# Altium schematic sheet [10] - USB-JTAG, USB-UART.SchDoc("[10] - USB-JTAG, USB-UART")
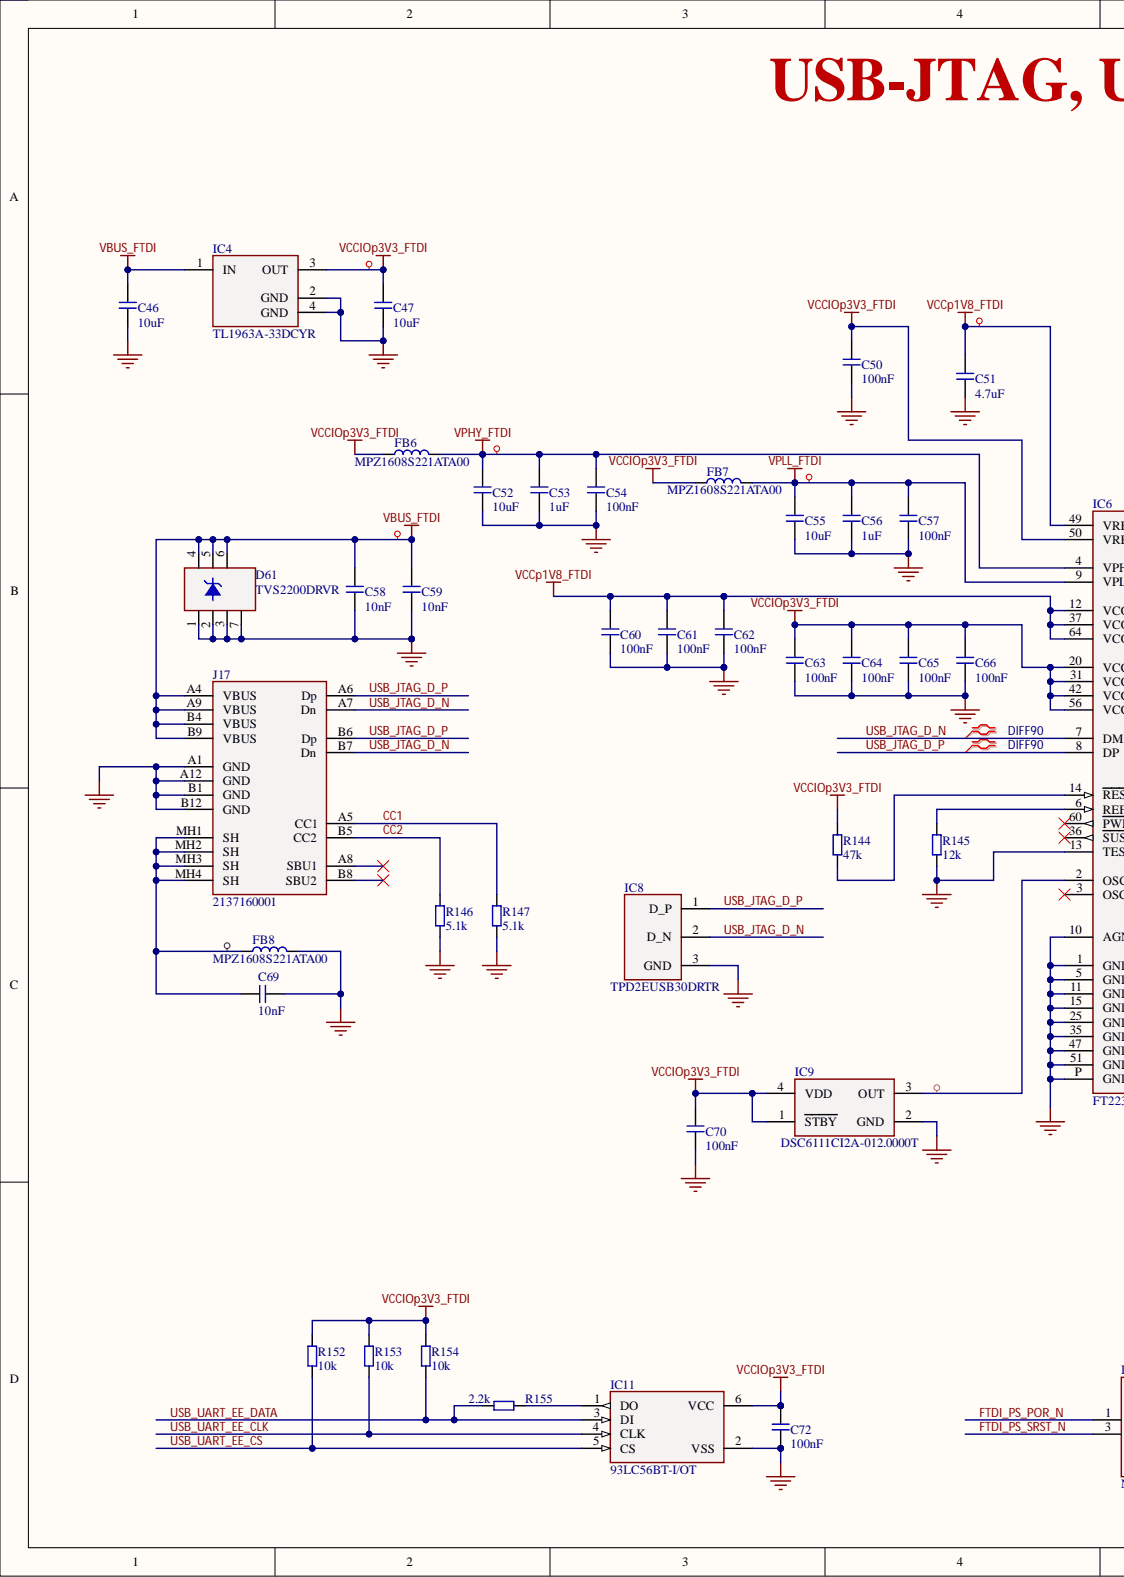
[diagram p0: [10] - USB-JTAG, USB-UART.SchDoc, left]
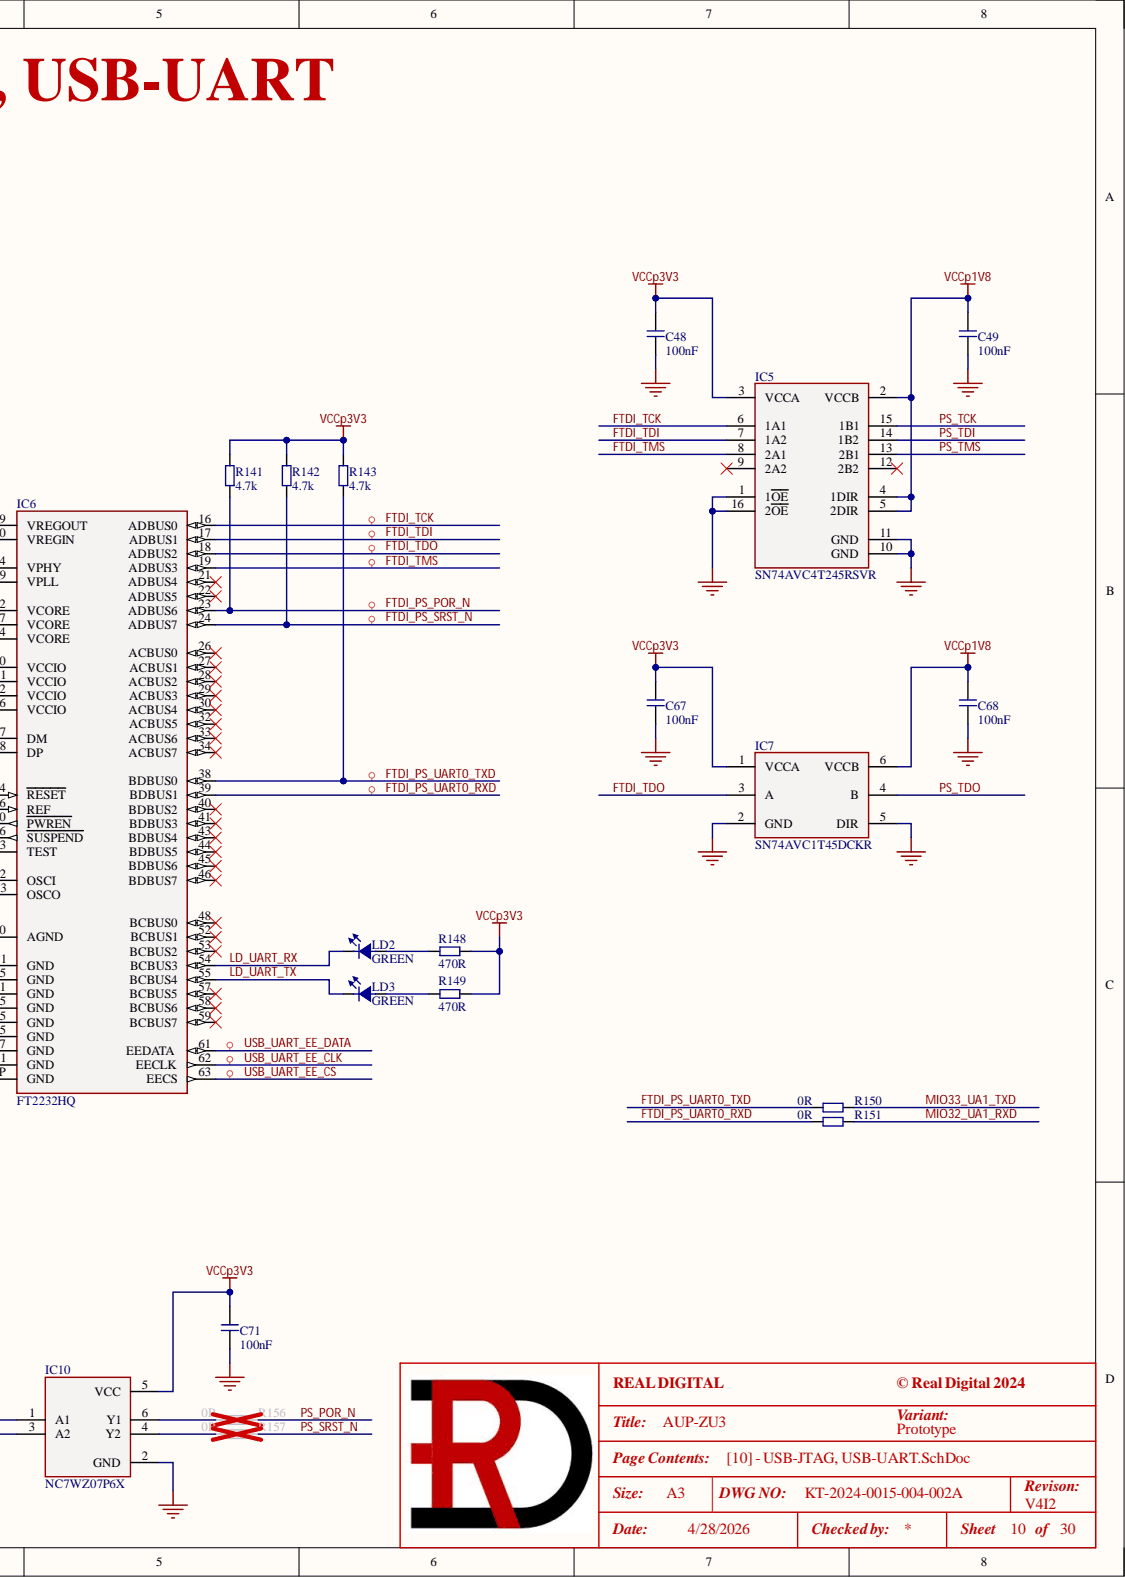
[diagram p1: [10] - USB-JTAG, USB-UART.SchDoc, right]

## Parts
C46  10uF  [C0805]
C47  10uF  [C0402]
C48  100nF  [C0402]
C49  100nF  [C0402]
C50  100nF  [C0402]
C51  4.7uF  [C0402]
C52  10uF  [C0805]
C53  1uF  [C0603]
C54  100nF  [C0402]
C55  10uF  [C0805]
C56  1uF  [C0603]
C57  100nF  [C0402]
C58  10nF  [C0402]
C59  10nF  [C0402]
C60  100nF  [C0402]
C61  100nF  [C0402]
C62  100nF  [C0402]
C63  100nF  [C0402]
C64  100nF  [C0402]
C65  100nF  [C0402]
C66  100nF  [C0402]
C67  100nF  [C0402]
C68  100nF  [C0402]
C69  10nF  [C0402]
C70  100nF  [C0402]
C71  100nF  [C0402]
C72  100nF  [C0402]
D61  TVS2200DRVR  [DRV0006A_WSON_6 - TI]
FB6  MPZ1608S221ATA00  [MPZ1608]
FB7  MPZ1608S221ATA00  [MPZ1608]
FB8  MPZ1608S221ATA00  [MPZ1608]
IC4  TL1963A-33DCYR  [DCY0004A_SOT223-4 - TI]
IC5  SN74AVC4T245RSVR  [RSV0016A_UQFN_16 - TI]
IC6  FT2232HQ  [QFN64 - FTDI]
IC7  SN74AVC1T45DCKR  [DCK0006A_SC70-6 - TI]
IC8  TPD2EUSB30DRTR  [DRT0003A - TI]
IC9  DSC6111CI2A-012.0000T  [DSC3.2x2.5-4]
IC10  NC7WZ07P6X  [DCK0006A_SC70-6 - TI]
IC11  93LC56BT-I/OT  [SOT23-6 - Microchip]
J17  2137160001  [2137160001]
LD2  GREEN  [LD0603_Green]
LD3  GREEN  [LD0603_Green]
R141  4.7k  [R0402]
R142  4.7k  [R0402]
R143  4.7k  [R0402]
R144  47k  [R0402]
R145  12k  [R0402]
R146  5.1k  [R0402]
R147  5.1k  [R0402]
R148  470R  [R0402]
R149  470R  [R0402]
R150  0R  [R0402]
R151  0R  [R0402]
R152  10k  [R0402]
R153  10k  [R0402]
R154  10k  [R0402]
R155  2.2k  [R0402]
R156  0R  [R0402]
R157  0R  [R0402]

## Nets
GND: C46.1 C47.1 C48.1 C49.1 C50.1 C51.1 C52.1 C53.1 C54.1 C55.1 C56.1 C57.1 C58.1 C59.1 C60.1 C61.1 C62.1 C63.1 C64.1 C65.1 C66.1 C67.1 C68.1 C69.2 C70.1 C71.1 C72.1 D61.1 D61.2 D61.3 D61.7 FB8.2 IC4.2(GND) IC4.4(GND) IC5.1(1OE) IC5.10(GND) IC5.11(GND) IC5.16(2OE) IC6.1(GND) IC6.5(GND) IC6.10(AGND) IC6.11(GND) IC6.13(TEST) IC6.15(GND) IC6.25(GND) IC6.35(GND) IC6.47(GND) IC6.51(GND) IC6.P(GND) IC7.2(GND) IC7.5(DIR) IC8.3 IC9.2(GND) IC10.2(GND) IC11.2(VSS) J17.A1(GND) J17.A12(GND) J17.B1(GND) J17.B12(GND) R145.1 R146.1 R147.1
VBUS_FTDI: C46.2 C58.2 C59.2 D61.4 D61.5 D61.6 IC4.1(IN) J17.A4(VBUS) J17.A9(VBUS) J17.B4(VBUS) J17.B9(VBUS)
VCCIOp3V3_FTDI: C47.2 C50.2 C63.2 C64.2 C65.2 C66.2 C70.2 C72.2 FB6.1 FB7.1 IC4.3(OUT) IC6.20(VCCIO) IC6.31(VCCIO) IC6.42(VCCIO) IC6.50(VREGIN) IC6.56(VCCIO) IC9.1(STBY) IC9.4(VDD) IC11.6(VCC) R144.2 R152.2 R153.2 R154.2
VCCp1V8: C49.2 C68.2 IC5.2(VCCB) IC5.4(1DIR) IC5.5(2DIR) IC7.6(VCCB)
VCCp1V8_FTDI: C51.2 C60.2 C61.2 C62.2 IC6.12(VCORE) IC6.37(VCORE) IC6.49(VREGOUT) IC6.64(VCORE)
VCCp3V3: C48.2 C67.2 C71.2 IC5.3(VCCA) IC7.1(VCCA) IC10.5(VCC) R141.2 R142.2 R143.2 R148.2 R149.2
VPHY_FTDI: C52.2 C53.2 C54.2 FB6.2 IC6.4(VPHY)
VPLL_FTDI: C55.2 C56.2 C57.2 FB7.2 IC6.9(VPLL)
CC1: J17.A5(CC1) R147.2
CC2: J17.B5(CC2) R146.2
FTDI_PS_POR_N: IC6.23(ADBUS6) IC10.1(A1) R141.1
FTDI_PS_SRST_N: IC6.24(ADBUS7) IC10.3(A2) R142.1
FTDI_PS_UART0_RXD: IC6.39(BDBUS1) R151.1
FTDI_PS_UART0_TXD: IC6.38(BDBUS0) R143.1 R150.1
FTDI_TCK: IC5.6(1A1) IC6.16(ADBUS0)
FTDI_TDI: IC5.7(1A2) IC6.17(ADBUS1)
FTDI_TDO: IC6.18(ADBUS2) IC7.3(A)
FTDI_TMS: IC5.8(2A1) IC6.19(ADBUS3)
LD_UART_RX: IC6.54(BCBUS3) LD2.K
LD_UART_TX: IC6.55(BCBUS4) LD3.K
MIO32_UA1_RXD: R151.2
MIO33_UA1_TXD: R150.2
PS_POR_N: R156.2
PS_SRST_N: R157.2
PS_TCK: IC5.15(1B1)
PS_TDI: IC5.14(1B2)
PS_TDO: IC7.4(B)
PS_TMS: IC5.13(2B1)
USB_JTAG_D_N: IC6.7(DM) IC8.2 J17.A7(Dn) J17.B7(Dn)
USB_JTAG_D_P: IC6.8(DP) IC8.1 J17.A6(Dp) J17.B6(Dp)
USB_UART_EE_CLK: IC6.62(EECLK) IC11.4(CLK) R153.1
USB_UART_EE_CS: IC6.63(EECS) IC11.5(CS) R152.1
USB_UART_EE_DATA: IC6.61(EEDATA) IC11.3(DI) R154.1 R155.2
NetC69_1: C69.1 FB8.1 J17.MH1(SH) J17.MH2(SH) J17.MH3(SH) J17.MH4(SH)
NetIC6_2: IC6.2(OSCI) IC9.3(OUT)
NetIC6_6: IC6.6(REF) R145.2
NetIC6_14: IC6.14(RESET) R144.1
NetIC10_4: IC10.4(Y2) R157.1
NetIC10_6: IC10.6(Y1) R156.1
NetIC11_1: IC11.1(DO) R155.1
NetLD2_A: LD2.A R148.1
NetLD3_A: LD3.A R149.1

Not connected: IC5 9(2A2) 12(2B2); IC6 3(OSCO) 21(ADBUS4) 22(ADBUS5) 26(ACBUS0) 27(ACBUS1) 28(ACBUS2) 29(ACBUS3) 30(ACBUS4) 32(ACBUS5) 33(ACBUS6) 34(ACBUS7) 36(SUSPEND) 40(BDBUS2) 41(BDBUS3) 43(BDBUS4) 44(BDBUS5) 45(BDBUS6) 46(BDBUS7) 48(BCBUS0) 52(BCBUS1) 53(BCBUS2) 57(BCBUS5) 58(BCBUS6) 59(BCBUS7) 60(PWREN); J17 A8(SBU1) B8(SBU2)

## Library data of the parts
C46 C52 C55 = GRM21BR71A106KA73L: CAP CER 10uF 10V X7R 0805; Manufacturer 1: Murata Electronics; .Checked_PCB: AC; .Creator: RV; C P/N: 111-0789; LoadStatus: Load; Tolerance: 10%; Category: Capacitors; Component Kind: Standard; Library Name: KT-RealDigital.IntLib; Manufacturer 1 Part Number: GRM21BR71A106KA73L; Temperature Coefficient: X7R; Voltage - Rated: 10V; .Checked_SCH: AC
C47 = GRM155R60J106ME47D: CAP CER 10uF 6.3V X5R 0402; Manufacturer 1: Murata Electronics; .Checked_PCB: RV; C P/N: RD-111-0021; LoadStatus: Load; Tolerance: 20%; Category: Capacitors; Component Kind: Standard; Library Name: KT-RealDigital.IntLib; Manufacturer 1 Part Number: GRM155R60J106ME47D; Temperature Coefficient: X5R; Voltage - Rated: 6.3V; .Checked_SCH: RV; .Creator: RV
C48-C50 C54 C57 C60-C68 C70-C72 = GRM155R71E104KE14J: CAP CER 0.1uF 25V X7R 0402; Manufacturer 1: Murata Electronics; .Checked_PCB: AC; .Creator: RV; C P/N: RD-111-0006; LoadStatus: Load; Tolerance: 10%; Category: Capacitors; Component Kind: Standard; Library Name: KT-RealDigital.IntLib; Manufacturer 1 Part Number: GRM155R71E104KE14J; Temperature Coefficient: X7R; Voltage - Rated: 25V; .Checked_SCH: AC
C51 = GRM155R60J475ME47J: CAP CER 4.7uF 6.3V X5R 0402; Manufacturer 1: Murata Electronics; .Checked_PCB: RV; C P/N: RD-111-0022; LoadStatus: Load; Tolerance: 20%; Category: Capacitors; Component Kind: Standard; Library Name: KT-RealDigital.IntLib; Manufacturer 1 Part Number: GRM155R60J475ME47J; Temperature Coefficient: X5R; Voltage - Rated: 6.3V; .Checked_SCH: RV; .Creator: RV
C53 C56 = GCJ188R71E105KA01D: CAP CER 1uF 25V X7R 0603; Manufacturer 1: Murata Electronics; .Checked_PCB: AC; .Creator: RV; C P/N: 111-0602; LoadStatus: Load; Tolerance: 10%; Category: Capacitors; Component Kind: Standard; Library Name: KT-RealDigital.IntLib; Manufacturer 1 Part Number: GCJ188R71E105KA01D; Temperature Coefficient: X7R; Voltage - Rated: 25V; .Checked_SCH: AC
C58 C59 C69 = GRM155R71H103KA88J: CAP CER 10000pF 50V X7R 0402; Manufacturer 1: Murata Electronics; .Checked_PCB: AC; .Creator: RV; C P/N: RD-111-0003; LoadStatus: Load; Tolerance: 10%; Category: Capacitors; Component Kind: Standard; Library Name: KT-RealDigital.IntLib; Manufacturer 1 Part Number: GRM155R71H103KA88J; Temperature Coefficient: X7R; Voltage - Rated: 50V; .Checked_SCH: AC
D61 = TVS2200DRVR: UNIDIR PRECISION SURGE DIODE; Manufacturer 1: Texas Instruments; .Checked_PCB: RV; C P/N: RD-121-0013; LoadStatus: Load; Category: TVS - Diodes; Component Kind: Standard; Library Name: KT-RealDigital.IntLib; Manufacturer 1 Part Number: TVS2200DRVR; .Checked_SCH: RV; .Creator: RV
FB6-FB8 = MPZ1608S221ATA00: FERRITE BEAD 220 OHM 0603 1LN; Manufacturer 1: TDK Corporation; .Checked_PCB: AC; .Checked_SCH: RV; C P/N: CY-121-0068; LoadStatus: Load; Category: Ferrite Beads; Component Kind: Standard; Library Name: KT-RealDigital.IntLib; Manufacturer 1 Part Number: MPZ1608S221ATA00; .Creator: RV
IC4 = TL1963A-33DCYR: IC REG LINEAR 3.3V 1.5A SOT223-4; Manufacturer 1: Texas Instruments; .Checked_PCB: RV; C P/N: RD-126-0009; LoadStatus: Load; Category: IC; Component Kind: Standard; Library Name: KT-RealDigital.IntLib; Manufacturer 1 Part Number: TL1963A-33DCYR; .Checked_SCH: RV; .Creator: RV
IC5 = SN74AVC4T245RSVR: IC TRNSLTR BIDIRECTIONAL 16UQFN; Manufacturer 1: Texas Instruments; .Checked_PCB: RV; C P/N: RD-126-1510; LoadStatus: Load; Category: IC; Component Kind: Standard; Library Name: KT-RealDigital.IntLib; Manufacturer 1 Part Number: SN74AVC4T245RSVR; .Checked_SCH: RV; .Creator: RV
IC6 = FT2232HQ: IC USB HS DUAL UART/FIFO 64-QFN; Manufacturer 1: FTDI; Manufacturer 1 Part Number: FT2232HQ-REEL; .Checked_PCB: AC; .Creator: RV; C P/N: 126-0695; LoadStatus: Load; Category: IC; Component Kind: Standard; Library Name: KT-RealDigital.IntLib; .Checked_SCH: AC
IC7 = SN74AVC1T45DCKR: IC TRNSLTR BIDIRECTIONAL SC70-6; Manufacturer 1: Texas Instruments; .Checked_PCB: RV; C P/N: RD-126-0010; LoadStatus: Load; Category: IC; Component Kind: Standard; Library Name: KT-RealDigital.IntLib; Manufacturer 1 Part Number: SN74AVC1T45DCKR; .Checked_SCH: RV; .Creator: RV
IC8 = TPD2EUSB30DRTR: TVS DIODE 5.5V 8V SOT3; Manufacturer 1: Texas Instruments; .Checked_PCB: RV; C P/N: 121-0524; LoadStatus: Load; Category: TVS - Diodes; Component Kind: Standard; Library Name: KT-RealDigital.IntLib; Manufacturer 1 Part Number: TPD2EUSB30DRTR; .Checked_SCH: RV; .Creator: RV
IC9 = DSC6111CI2A-012.0000T: 12MHz XO (Standard) CMOS Oscillator 1.8V ~ 3.3V Standby (Power Down) 4-SMD, No Lead; Manufacturer 1: Microchip; Manufacturer 2 Part Number: SMA-012000-3CY4T1; .Checked_PCB: AC; .Creator: RV; C P/N: 126-1425; LoadStatus: Load; Category: Oscillators; Component Kind: Standard; Library Name: KT-RealDigital.IntLib; Manufacturer 1 Part Number: DSC6111CI2A-012.0000T; Manufacturer 2: AKER TECHNOLOGY CO., LTD.; .Checked_SCH: AC
IC10 = NC7WZ07P6X: IC BUF NON-INVERT 5.5V SC70-6; Manufacturer 1: ON Semiconductor; .Checked_PCB: RV; C P/N: 126-0507; LoadStatus: Load; Category: IC; Component Kind: Standard; Library Name: KT-RealDigital.IntLib; Manufacturer 1 Part Number: NC7WZ07P6X; .Checked_SCH: RV; .Creator: RV
IC11 = 93LC56BT-I/OT: 2K Microwire Compatible Serial EEPROM; Manufacturer 1: Microchip; .Checked_PCB: AC; .Creator: RV; C P/N: 126-0703; LoadStatus: Load; Category: IC; Component Kind: Standard; Library Name: KT-RealDigital.IntLib; Manufacturer 1 Part Number: 93LC56BT-I/OT; .Checked_SCH: AC
J17 = 2137160001: CONN RCPT USB2.0 TYPE C 24POS RA; Manufacturer 1: Molex; .Checked_PCB: RV; C P/N: RD-163-0020; LoadStatus: Load; Category: Connectors; Component Kind: Standard; KT P/N: RD-163-0020; Library Name: KT-RealDigital.IntLib; Manufacturer 1 Part Number: 2137160001; .Checked_SCH: RV; .Creator: RV
LD2 LD3 = LED_GREEN: LED GREEN 0603; Manufacturer 1: Everlight Electronics CO., LTD; Manufacturer 1 Part Number: 19-21SYGC/S530-E4/TR8; .Checked_PCB: AC; .Creator: RV; C P/N: 121-0006; LoadStatus: Load; Category: Led; Component Kind: Standard; Library Name: KT-RealDigital.IntLib; .Checked_SCH: AC
R141-R143 = RC0402FR-074K7P: RES SMD 4.7K OHM 1% 1/16W 0402; Manufacturer 1: Yageo; .Checked_PCB: AC; .Creator: RV; C P/N: 101-0129; LoadStatus: Load; Tolerance: 1%/TK100; Category: Resistors; Component Kind: Standard; Library Name: KT-RealDigital.IntLib; Manufacturer 1 Part Number: RC0402FR-074K7P; .Checked_SCH: AC
R144 = RC0402JR-0747KL: RES SMD 47K OHM 5% 1/16W 0402; Manufacturer 1: Yageo; .Checked_PCB: AC; .Creator: RV; C P/N: 101-0074; LoadStatus: Load; Tolerance: 5%/TK100; Category: Resistors; Component Kind: Standard; Library Name: KT-RealDigital.IntLib; Manufacturer 1 Part Number: RC0402JR-0747KL; .Checked_SCH: AC
R145 = RC0402FR-0712KL: RES SMD 12K OHM 1% 1/16W 0402; Manufacturer 1: Yageo; .Checked_PCB: AC; .Creator: RV; C P/N: 101-0643; LoadStatus: Load; Tolerance: 1%/TK100; Category: Resistors; Component Kind: Standard; Library Name: KT-RealDigital.IntLib; Manufacturer 1 Part Number: RC0402FR-0712KL; .Checked_SCH: AC
R146 R147 = RC0402FR-135K1L: RES SMD 5.1K OHM 1% 1/16W 0402; Manufacturer 1: YAGEO; .Checked_PCB: RV; C P/N: RD-101-0072; LoadStatus: Load; Tolerance: 1%/TK100; Category: Resistors; Component Kind: Standard; Library Name: KT-RealDigital.IntLib; Manufacturer 1 Part Number: RC0402FR-135K1L; .Checked_SCH: RV; .Creator: RV
R148 R149 = RC0402JR-07470RL: RES SMD 470 OHM 5% 1/16W 0402; Manufacturer 1: Yageo; .Checked_PCB: AC; .Creator: RV; C P/N: 101-0052; LoadStatus: Load; Tolerance: 5%/TK100; Category: Resistors; Component Kind: Standard; Library Name: KT-RealDigital.IntLib; Manufacturer 1 Part Number: RC0402JR-07470RL; .Checked_SCH: AC
R150 R151 R156 R157 = RC0402FR-070RL: RES SMD 0 OHM JUMPER 1/16W 0402; Manufacturer 1: YAGEO; .Checked_PCB: AC; .Creator: RV; C P/N: RD-101-0003; LoadStatus: Load; Category: Resistors; Component Kind: Standard; Library Name: KT-RealDigital.IntLib; Manufacturer 1 Part Number: RC0402FR-070RL; Tolerance: Jumper; .Checked_SCH: AC
R152-R154 = RC0402JR-0710KL: RES SMD 10K OHM 5% 1/16W 0402; Manufacturer 1: Yageo; .Checked_PCB: AC; .Creator: RV; C P/N: 101-0047; LoadStatus: Load; Tolerance: 5%/TK100; Category: Resistors; Component Kind: Standard; Library Name: KT-RealDigital.IntLib; Manufacturer 1 Part Number: RC0402JR-0710KL; .Checked_SCH: AC
R155 = RC0402JR-072K2L: RES SMD 2.2K OHM 5% 1/16W 0402; Manufacturer 1: Yageo; .Checked_PCB: AC; .Creator: RV; C P/N: RD-101-0005; LoadStatus: Load; Tolerance: 5%/TK100; Category: Resistors; Component Kind: Standard; Library Name: KT-RealDigital.IntLib; Manufacturer 1 Part Number: RC0402JR-072K2L; .Checked_SCH: AC
